# Altium schematic sheet 11_GTX&PL _CLK.SchDoc
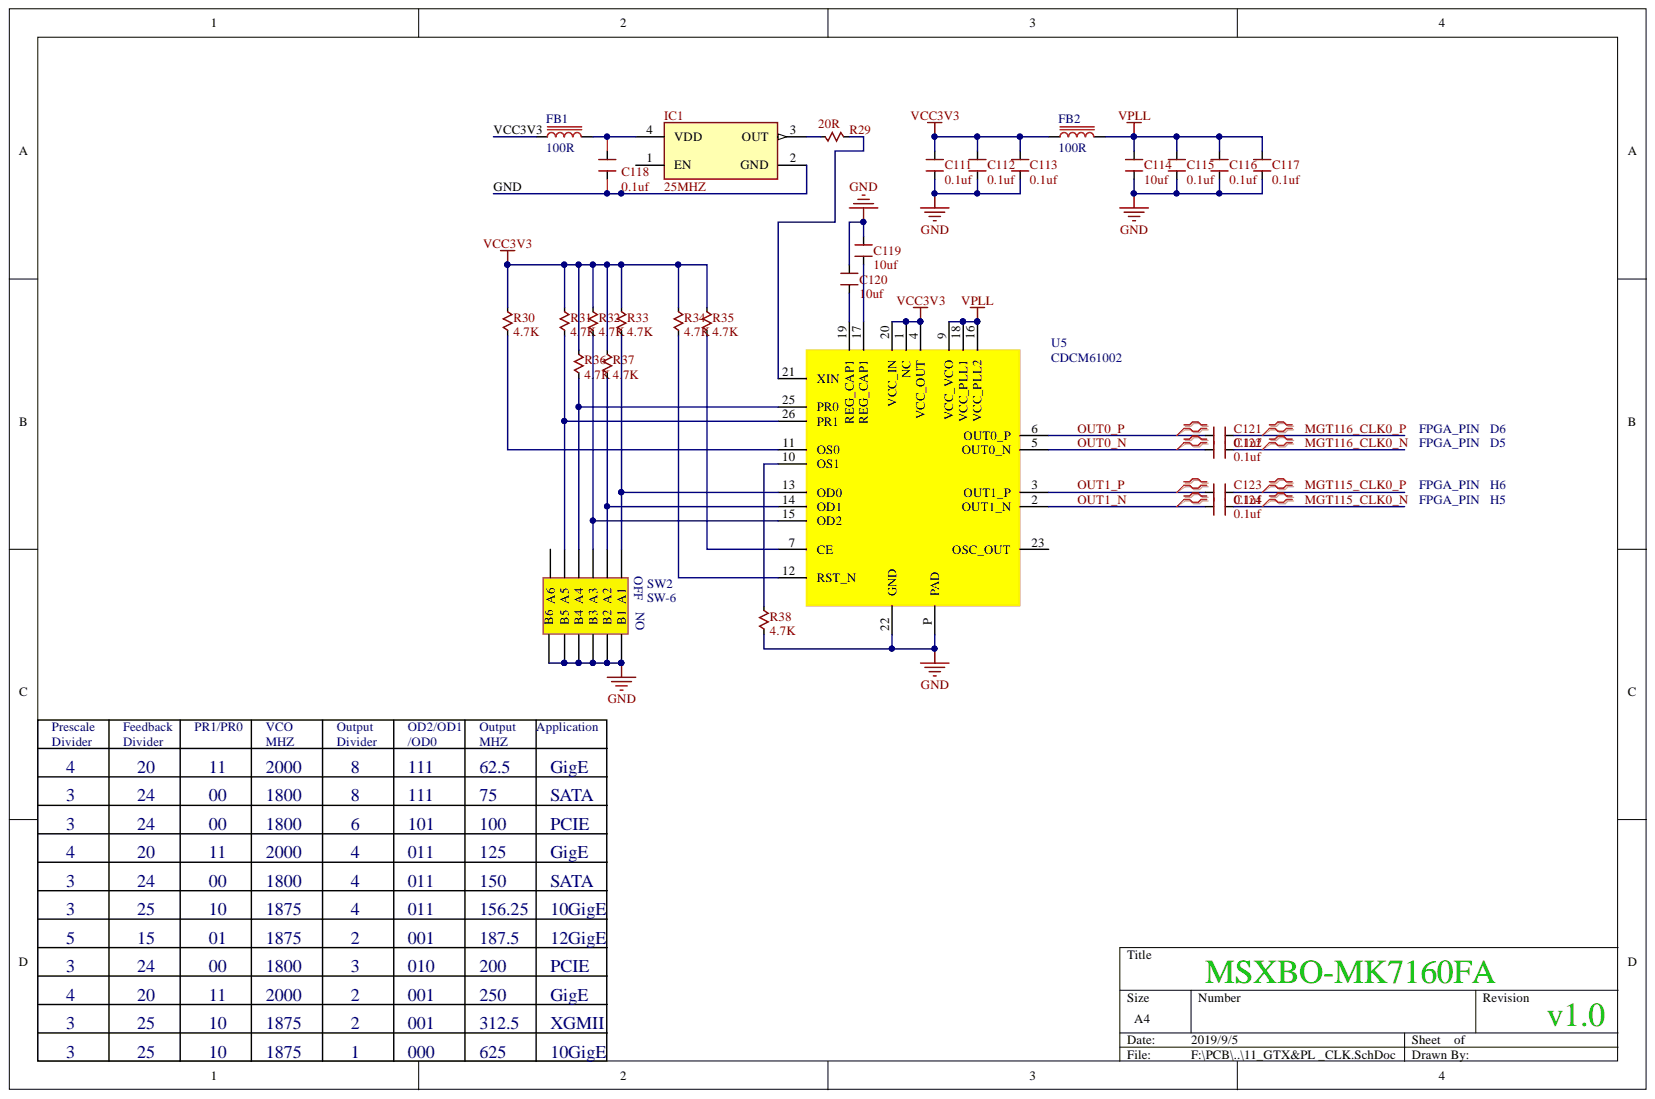
[diagram p0: 11_GTX&PL _CLK.SchDoc]

## Parts
C111  0.1uf  [CAP0402]
C112  0.1uf  [CAP0402]
C113  0.1uf  [CAP0402]
C114  10uf  [CAP0402]
C115  0.1uf  [CAP0402]
C116  0.1uf  [CAP0402]
C117  0.1uf  [CAP0402]
C118  0.1uf  [CAP0402]
C119  10uf  [CAP0402]
C120  10uf  [CAP0402]
C121  0.1uf  [CAP0402]
C122  0.1uf  [CAP0402]
C123  0.1uf  [CAP0402]
C124  0.1uf  [CAP0402]
FB1  100R  [CAP0603]
FB2  100R  [CAP0603]
IC1  25MHZ  [3225]
R29  20R  [RES0402]
R30  4.7K  [RES0402]
R31  4.7K  [RES0402]
R32  4.7K  [RES0402]
R33  4.7K  [RES0402]
R34  4.7K  [RES0402]
R35  4.7K  [RES0402]
R36  4.7K  [RES0402]
R37  4.7K  [RES0402]
R38  4.7K  [RES0402]
SW2  SW-6  [SW-6]
U5  CDCM61002  [QFN32 - C]

## Nets
VPLL: C114.2 C115.2 C116.2 C117.2 FB2.2 U5.9(VCC_VCO) U5.16(VCC_PLL2) U5.18(VCC_PLL1)
GND: C111.1 C112.1 C113.1 C114.1 C115.1 C116.1 C117.1 C118.2 C119.2 C120.2 IC1.2(GND) R38.2 SW2.B1 SW2.B2 SW2.B3 SW2.B4 SW2.B5 SW2.B6 U5.22(GND) U5.P(PAD)
MGT115_CLK0_N: C124.1
MGT115_CLK0_P: C123.1
MGT116_CLK0_N: C122.1
MGT116_CLK0_P: C121.1
OUT0_N: C122.2 U5.5(OUT0_N)
OUT0_P: C121.2 U5.6(OUT0_P)
OUT1_N: C124.2 U5.2(OUT1_N)
OUT1_P: C123.2 U5.3(OUT1_P)
VCC3V3: C111.2 C112.2 C113.2 FB1.1 FB2.1 R30.1 R31.1 R32.1 R33.1 R34.1 R35.1 R36.1 R37.1 U5.1(NC) U5.4(VCC_OUT) U5.20(VCC_IN)
NetC118_1: C118.1 FB1.2 IC1.4(VDD)
NetC119_1: C119.1 U5.17(REG_CAP1)
NetC120_1: C120.1 U5.19(REG_CAP1)
NetIC1_3: IC1.3(OUT) R29.1
NetR29_2: R29.2 U5.21(XIN)
NetR30_2: R30.2 U5.11(OS0)
NetR31_2: R31.2 SW2.A5 U5.26(PR1)
NetR32_2: R32.2 SW2.A3 U5.15(OD2)
NetR33_2: R33.2 SW2.A1 U5.13(OD0)
NetR34_2: R34.2 U5.12(RST_N)
NetR35_2: R35.2 U5.7(CE)
NetR36_2: R36.2 SW2.A4 U5.25(PR0)
NetR37_2: R37.2 SW2.A2 U5.14(OD1)
NetR38_1: R38.1 U5.10(OS1)
D5:
D6:
FPGA_PIN:
H5:
H6:

Not connected: IC1 1(EN); SW2 A6; U5 23(OSC_OUT)

## Library data of the parts
C111-C117 C119-C124 = CAPACITOR: Generic Capacitor; Component Kind: Standard; Library Name: M7Z100.IntLib
  C111-C113 C115-C117 C121-C124: Value: 0.1uf
  C114 C119 C120: Value: 10uf
C118 = CAPACITOR: Generic Capacitor; Value: 10nF; Component Kind: Standard; Library Name: Digilent.IntLib
FB1 FB2 = Ferrite Bead: Generic Ferrite Bead; Value: 600; Component Kind: Standard; Library Name: M7Z100.IntLib
IC1 = FXO-HC5: 3.3V HCMOS Oscillator; Manufacturer: Fox Electronics; Value: 33.33MHz; Component Kind: Standard; Library Name: Digilent.IntLib
R29 = RESISTOR: Generic Resistor; Value: 24; Component Kind: Standard; Library Name: Digilent.IntLib
R30-R38 = RESISTOR: Generic Resistor; Component Kind: Standard; Library Name: M7Z100.IntLib; Value: 4.7K
SW2 = SW6: Component Kind: Standard; Library Name: M7Z100.IntLib
U5 = CDCM61002: Component Kind: Standard; Library Name: M7Z100.IntLib
